# Altium schematic sheet Power Schematic.SchDoc("Power Schematic")
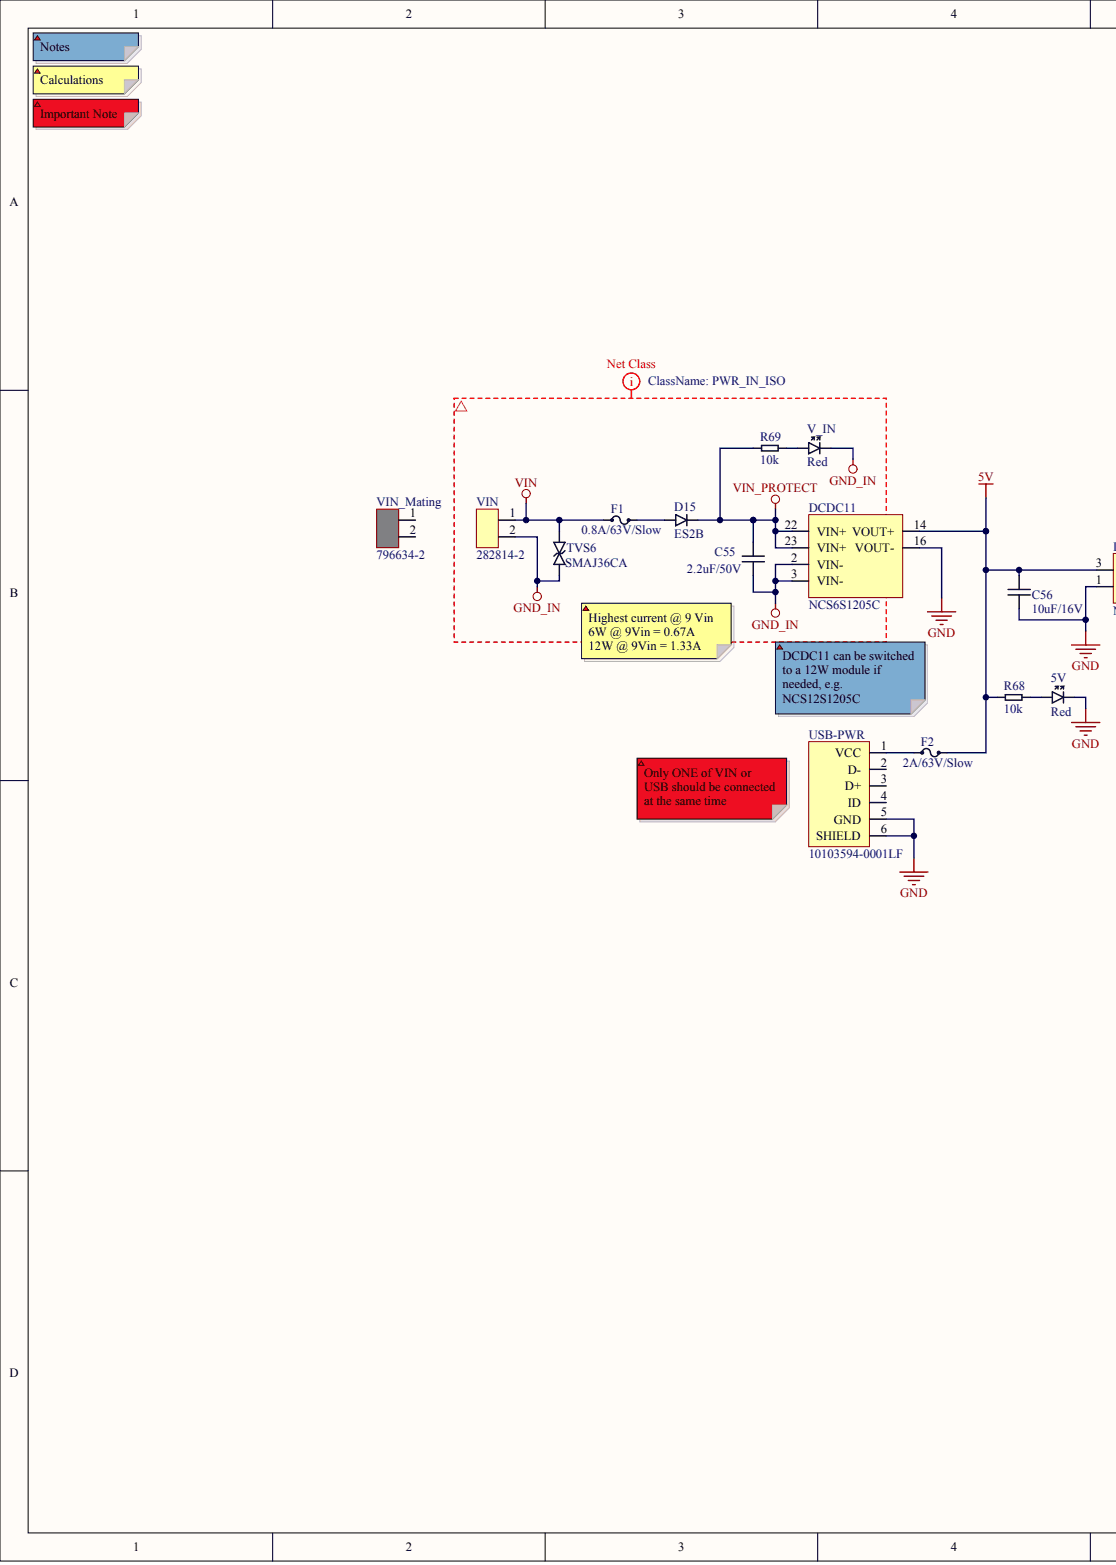
[diagram p0: Power Schematic.SchDoc, left]
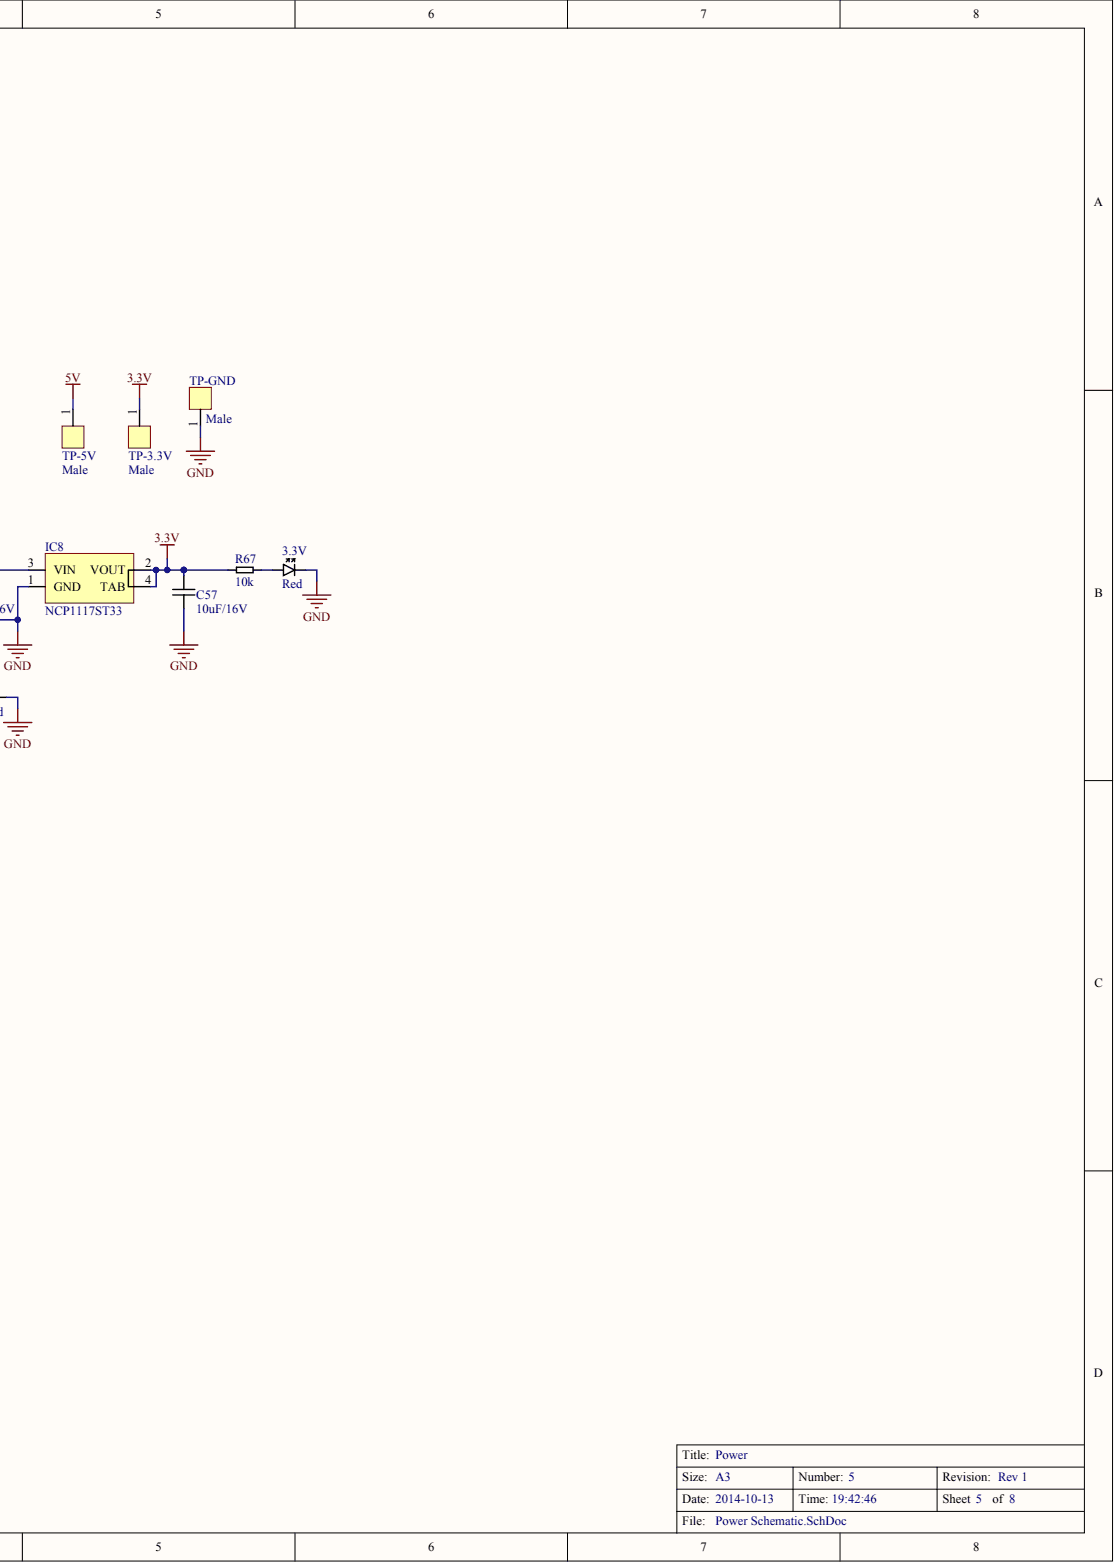
[diagram p1: Power Schematic.SchDoc, right]

## Parts
3.3V  value Red  (hidden comment: LED_0603_Red)
5V  value Red  (hidden comment: LED_0603_Red)
C55  value 2.2uF/50V  [1206]  (hidden comment: Cap_1206_2.2uF/50V)
C56  value 10uF/16V  [0805]  (hidden comment: Cap_0805_10uF/16V)
C57  value 10uF/16V  [0805]  (hidden comment: Cap_0805_10uF/16V)
D15  ES2B
DCDC11  NCS6S1205C  [24 DIP Module (8 Leads)]
F1  value 0.8A/63V/Slow  [SMD 1206]  (hidden comment: Fuse_1206_0.8A_63V_Slow)
F2  value 2A/63V/Slow  [SMD 1206]  (hidden comment: Fuse_1206_2A_63V_Slow)
IC8  NCP1117ST33
R67  value 10k  [0603]  (hidden comment: Res_0603_10k)
R68  value 10k  [0603]  (hidden comment: Res_0603_10k)
R69  value 10k  [0603]  (hidden comment: Res_0603_10k)
TP-3.3V  value Male  (hidden comment: Connector 1x1)
TP-5V  value Male  (hidden comment: Connector 1x1)
TP-GND  value Male  (hidden comment: Connector 1x1)
TVS6  SMAJ36CA
USB-PWR  value 10103594-0001LF  (hidden comment: USB Micro 10103594-0001LF)
V_IN  value Red  (hidden comment: LED_0603_Red)
VIN  value 282814-2  Part No.: 282814-2  (hidden comment: Terminal Block 1x2 5.08mm)
VIN_Mating  value 796634-2  Part No.: 796634-2  (hidden comment: Terminal Block 1x2 5.08mm Mating Connector)

## Nets
3.3V: C57.2 IC8.2(VOUT) IC8.4(TAB) R67.2 TP-3.3V.1
5V: C56.2 DCDC11.14(VOUT+) F2.2 IC8.3(VIN) R68.2 TP-5V.1
GND: 3.3V.CATHODE 5V.CATHODE C56.1 C57.1 DCDC11.16(VOUT-) IC8.1(GND) TP-GND.1 USB-PWR.5(GND) USB-PWR.6(SHIELD)
GND_IN: C55.1 DCDC11.2(VIN-) DCDC11.3(VIN-) TVS6.1 V_IN.CATHODE VIN.2
VIN: F1.1 TVS6.2 VIN.1
VIN_PROTECT: C55.2 D15.1 DCDC11.22(VIN+) DCDC11.23(VIN+) R69.2
Net3.3V_ANODE: 3.3V.ANODE R67.1
Net5V_ANODE: 5V.ANODE R68.1
NetD15_2: D15.2 F1.2
NetF2_1: F2.1 USB-PWR.1(VCC)
NetR69_1: R69.1 V_IN.ANODE
N00164:

Not connected: USB-PWR 2(D-) 3(D+) 4(ID); VIN_Mating 1 2

## Library data of the parts
D15 = (no symbol name): Manufacturer: Vishay; Part No.: 625-ES2B-E3/5BT; Mouser: ES2B-E3/5BT; DigiKey: ES2B-E3/52TGICT-ND; Price SEK (No VAT): 4; Value: ES2B
DCDC11 = (no symbol name): Manufacturer: Murata; RS: 689-4823; DigiKey: 811-2109-5-ND; Part No.: NCS6S1205C; Price SEK (No VAT): 157; Mouser: 580-NCS6S1205C; Datasheet: http://www.murata-ps.com/data/power/ncl/kdc_ncs6.pdf; Farnell: *
F1 = (no symbol name): Part No.: SF-1206S080-2; Manufacturer: Bourns; Mouser: 652-SF-1206S080-2; Price SEK (No VAT): 5; DigiKey: SF-1206S080-2CT-ND; RS: *; Farnell: 2291894
F2 = (no symbol name): Part No.: SF-1206S200-2; Manufacturer: Bourns; RS: 692-5053 (10-pack); Mouser: 652-SF-1206S200-2; Price SEK (No VAT): 5; DigiKey: SF-1206S200-2CT-ND; Farnell: 1652161
IC8 = (no symbol name): Part No.: NCP1117ST33T3G; Manufacturer: ON Semiconductor; RS: 785-7207 (20-pack); DigiKey: NCP1117ST33T3GOSCT-ND; Price SEK (No VAT): 4; Datasheet: http://www.onsemi.com/pub_link/Collateral/NCP1117-D.PDF; Mouser: 863-NCP1117ST33T3G; Farnell: 1652366; Value: NCP1117ST33
TVS6 = (no symbol name): Manufacturer: Bourns; Mouser: 652-SMAJ36CA; DigiKey: SMAJ36CABCT-ND; Price SEK (No VAT): 4; Part No.: SMAJ36CA; Value: SMAJ36CA
USB-PWR = (no symbol name): Manufacturer: FCI; RS: 714-2347; Mouser: 649-10103594-0001LF; DigiKey: 609-4050-1-ND; Farnell: 2293752; Price SEK (No VAT): 5; Part No.: 10103594-0001LF
VIN = (no symbol name): Manufacturer: TE Connectivity; Mating Connector: 796634-2; RS: 710-0393 (10-pack); Mouser: 571-282814-2; DigiKey: A98065-ND; Elfa: *; Price SEK (No VAT): 4
VIN_Mating = (no symbol name): Manufacturer: TE Connectivity; RS: 361-7320 (5-pack); Mouser: 571-7966342; DigiKey: A98223-ND; Elfa: *; Price SEK (No VAT): 10; Farnell: *
3.3V 5V C55-C57 R67-R69 TP-3.3V TP-5V TP-GND V_IN = (no symbol name)
  R67-R69: Price (No VAT): 0,1
